# Altium schematic sheet Sheet2.SchDoc(Sheet2)
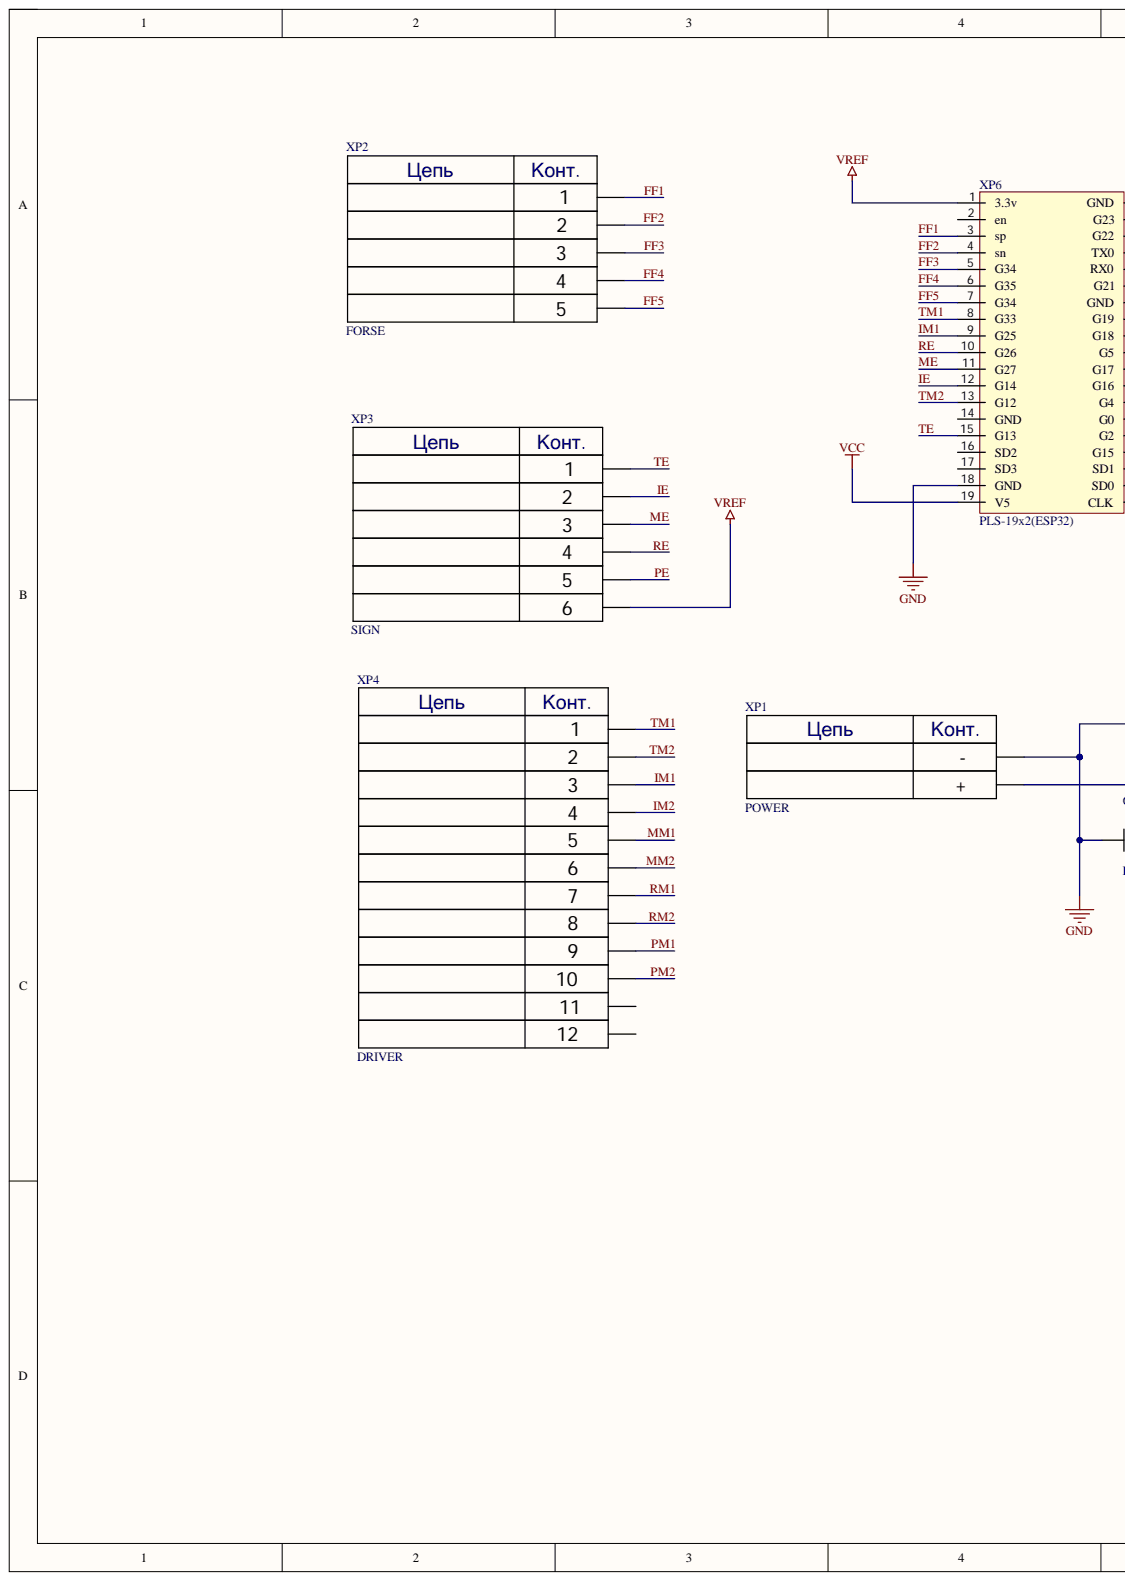
[diagram p0: Sheet2.SchDoc, left]
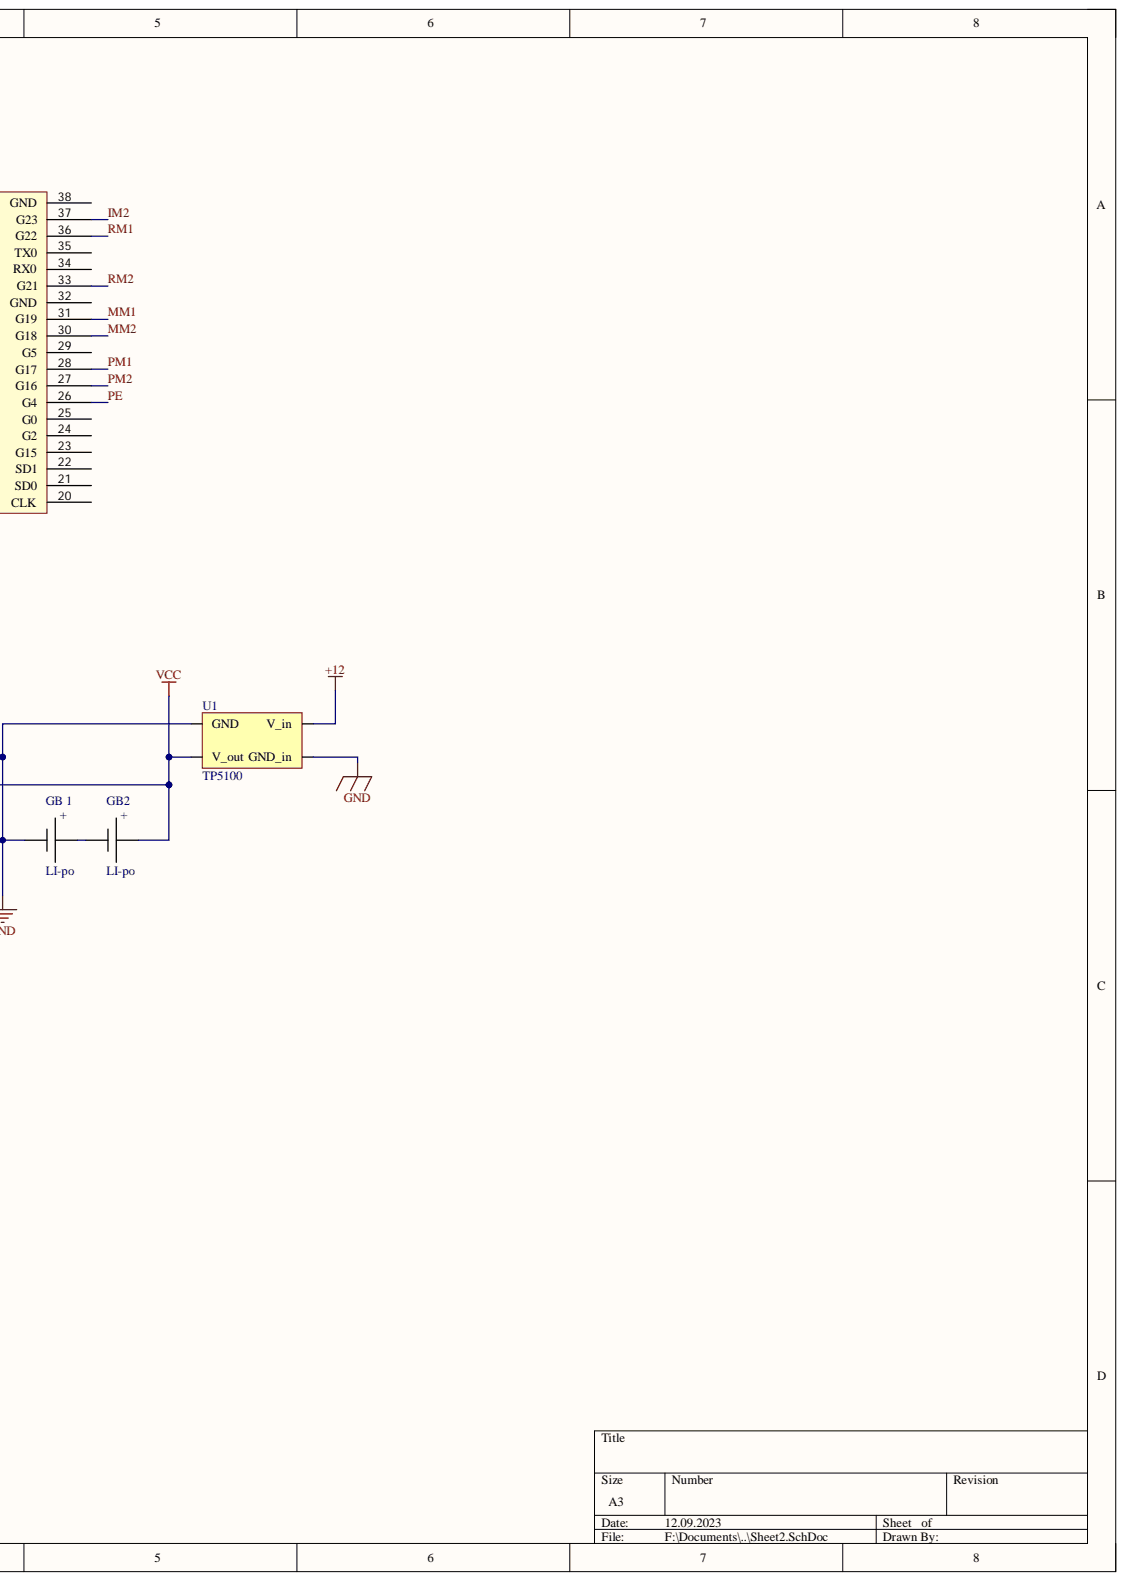
[diagram p1: Sheet2.SchDoc, right]

## Parts
GB2  LI-po
GB_1  LI-po
U1  TP5100
XP1  POWER  [XT30PW-M]
XP2  FORSE  [B5B-XH-A]
XP3  SIGN
XP4  DRIVER  [IDC-12]
XP6  PLS-19x2(ESP32)  [PLS(ESP32)]

## Nets
+12: U1.4(V_in)
GND: GB_1.2 U1.2(GND) U1.3(GND_in) XP1.1 XP6.18(GND)
VCC: GB2.1 U1.1(V_out) XP1.2 XP6.19(V5)
VREF: XP3.6 XP6.1(3.3v)
FF1: XP2.1 XP6.3(sp)
FF2: XP2.2 XP6.4(sn)
FF3: XP2.3 XP6.5(G34)
FF4: XP2.4 XP6.6(G35)
FF5: XP2.5 XP6.7(G34)
IE: XP3.2 XP6.12(G14)
IM1: XP4.3 XP6.9(G25)
IM2: XP4.4 XP6.37(G23)
ME: XP3.3 XP6.11(G27)
MM1: XP4.5 XP6.31(G19)
MM2: XP4.6 XP6.30(G18)
PE: XP3.5 XP6.26(G4)
PM1: XP4.9 XP6.28(G17)
PM2: XP4.10 XP6.27(G16)
RE: XP3.4 XP6.10(G26)
RM1: XP4.7 XP6.36(G22)
RM2: XP4.8 XP6.33(G21)
TE: XP3.1 XP6.15(G13)
TM1: XP4.1 XP6.8(G33)
TM2: XP4.2 XP6.13(G12)
NetGB2_2: GB2.2 GB_1.1

Not connected: XP4 11 12; XP6 2(en) 14(GND) 16(SD2) 17(SD3) 20(CLK) 21(SD0) 22(SD1) 23(G15) 24(G2) 25(G0) 29(G5) 32(GND) 34(RX0) 35(TX0) 38(GND)

## Library data of the parts
U1 = li-po power TP5100: Component Kind: Standard; Library Name: Pakage_lib.SchLib
XP1 = XT30PW-M: Component Kind: Standard; Description: XT30PW-M; Library Name: Разъемы.SchLib
XP2 = PLS-5: Component Kind: Standard; Description: PLS-5; Library Name: Разъемы.SchLib
XP3 = 6pin: Component Kind: Standard; Library Name: Разъемы.SchLib
XP4 = PLD-12: Component Kind: Standard; Description: PLD-12; Library Name: Разъемы.SchLib
GB2 GB_1 = LI-po: Component Kind: Standard; Library Name: Pakage_lib.SchLib
XP6 = PLS-19x2(ESP32): Component Kind: Standard; Library Name: Разъемы.SchLib
